# Altium schematic sheet SENSORS.SchDoc
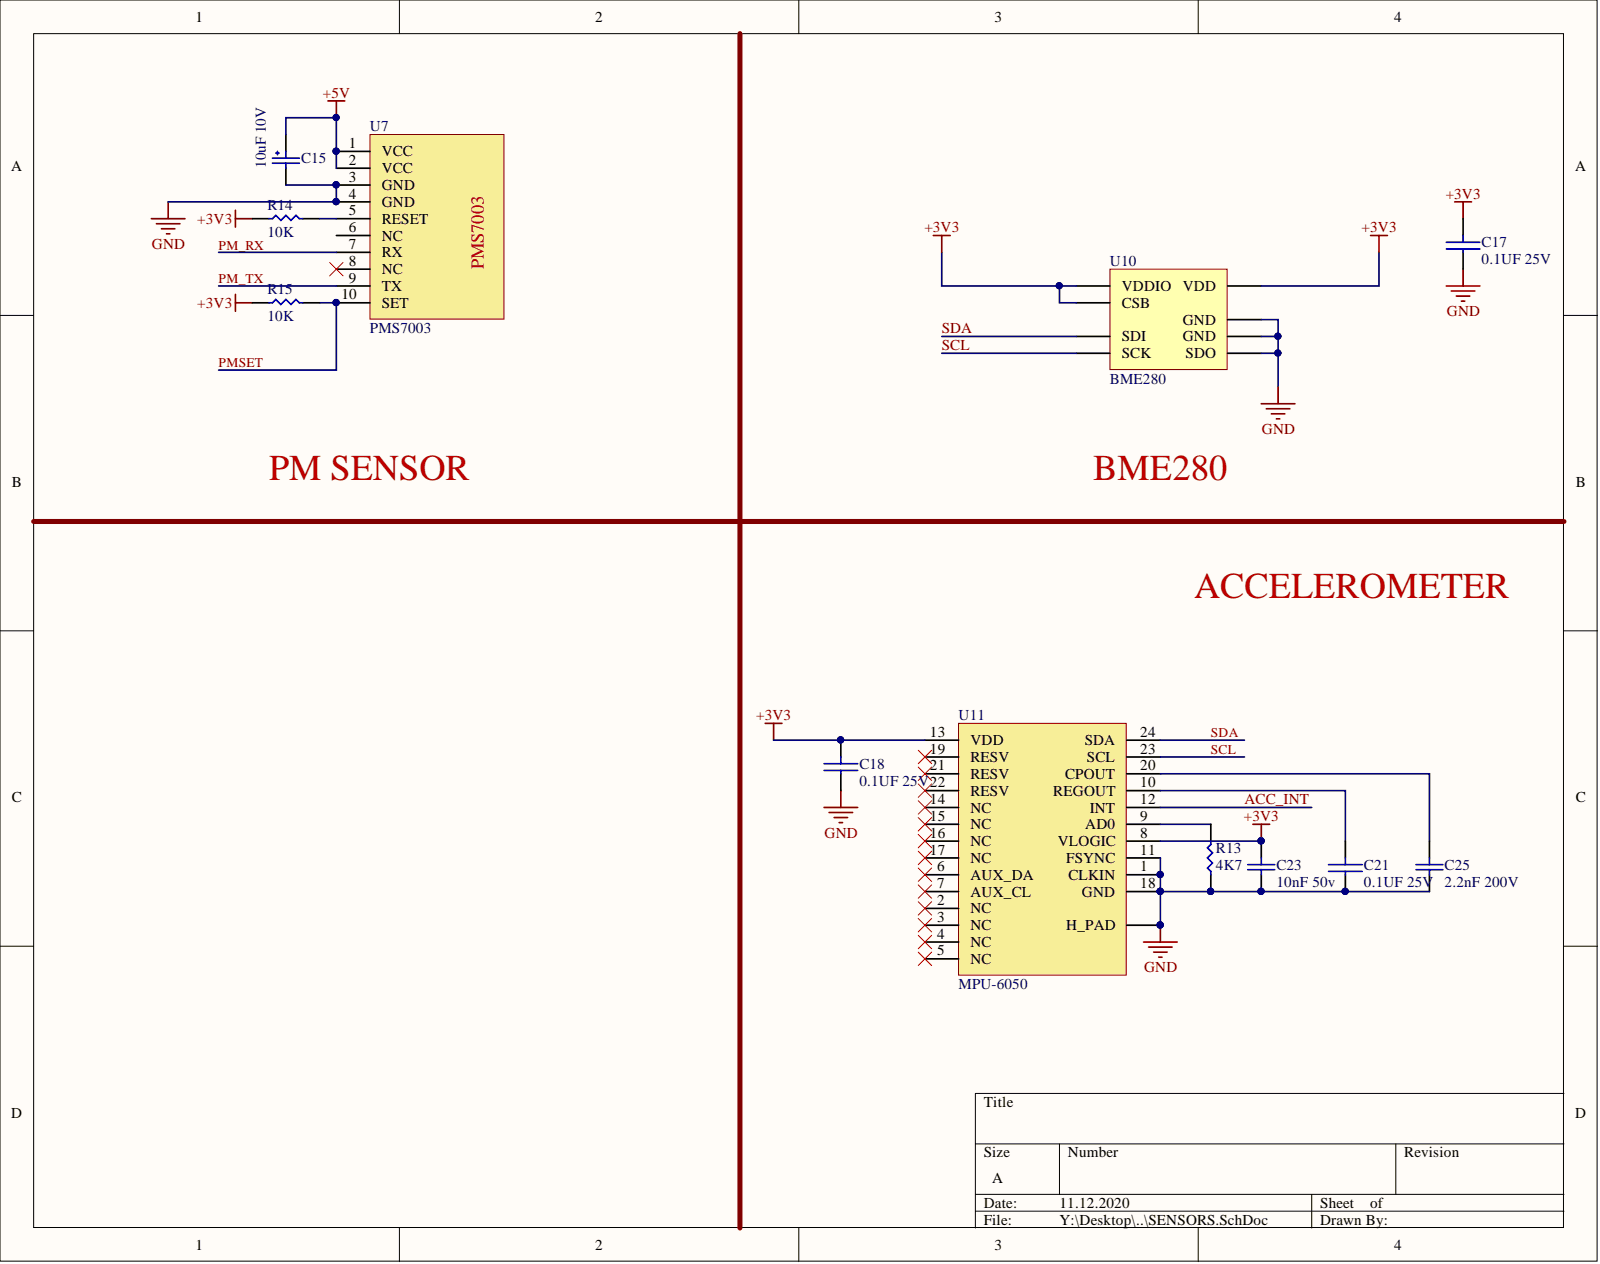
[diagram p0: SENSORS.SchDoc]

## Parts
C15  10uF 10V  [C3216]
C17  0.1UF 25V  [C0603]
C18  0.1UF 25V  [C0603]
C21  0.1UF 25V  [C0603]
C23  10nF 50v  [C0603]
C25  2.2nF 200V  [C0603]
R13  4K7  [R0603]
R14  10K  [R0603]
R15  10K  [R0603]
U7  PMS7003  [PMS7003]
U10  BME280  [BME280]
U11  MPU-6050  [MPU6050]

## Nets
+3V3: C17.2 C18.2 C23.2 R14.1 R15.1 U10.2(CSB) U10.6(VDDIO) U10.8(VDD) U11.8(VLOGIC) U11.13(VDD)
+5V: C15.2 U7.1(VCC) U7.2(VCC)
GND: C15.1 C17.1 C18.1 C21.1 C23.1 C25.1 R13.1 U7.3(GND) U7.4(GND) U10.1(GND) U10.5(SDO) U10.7(GND) U11.1(CLKIN) U11.11(FSYNC) U11.18(GND) U11.25(H_PAD)
ACC_INT: U11.12(INT)
PM_RX: U7.7(RX)
PM_TX: U7.9(TX)
PMSET: R15.2 U7.10(SET)
SCL: U10.4(SCK) U11.23(SCL)
SDA: U10.3(SDI) U11.24(SDA)
NetC21_2: C21.2 U11.10(REGOUT)
NetC25_2: C25.2 U11.20(CPOUT)
NetR13_2: R13.2 U11.9(AD0)
NetR14_2: R14.2 U7.5(RESET)
N00081:
N00082:

Not connected: U7 6(NC) 8(NC); U11 2(NC) 3(NC) 4(NC) 5(NC) 6(AUX_DA) 7(AUX_CL) 14(NC) 15(NC) 16(NC) 17(NC) 19(RESV) 21(RESV) 22(RESV)

## Library data of the parts
C15 = T491A106K010AT: CAP TANT 10UF 10V 10% 1206; Manufacturer: KEMET; Manufacturer Size Code: A; ESR (Equivalent Series Resistance): 3.8 Ohm; Lifetime @ Temp.: 2000 Hrs @ 85°C; Type: Molded; Category: Capacitors; Component Kind: Standard; ComponentLink1Description: http://www.kemet.com/Lists/ProductCatalog/Attachments/254/KEM_T2005_T491.pdf; ComponentLink1URL: http://www.kemet.com/Lists/ProductCatalog/Attachments/254/KEM_T2005_T491.pdf; Failure Rate: -; Features: General Purpose; Height - Seated (Max): 0.071" (1.80mm); Lead Spacing: -; Manufacturer Part Number: T491A106K010AT; Mounting Type: Surface Mount; Operating Temperature: -55°C ~ 125°C; Package / Case: 1206 (3216 Metric); Packaging: Cut Tape (CT); Part Status: Active; Pricing 1: 1=0.41, 10=0.287, 100=0.1721, 500=0.12912, 1000=0.10903 (USD); RoHS: RoHS Compliant; Size / Dimension: 0.126" L x 0.063" W (3.20mm x 1.60mm); Stock 1: 741056; Supplier 1: Digi-Key; Supplier Part Number 1: 399-3684-1-ND; Tolerance: ±10%; Library Name: salcox.IntLib
C17 C18 C21 = 06033D104KAT2A: CAP CER 0.1UF 25V X5R 0603; Manufacturer: Kyocera AVX; Capacitance: 100 nF; Tolerance (%): ±10 %; Case Size/Dimension: 0603; Component Kind: Standard; ComponentLink1Description: https://datasheet.ciiva.com/19475/1031061-19475906.pdf; ComponentLink1URL: https://datasheet.ciiva.com/19475/1031061-19475906.pdf; ComponentLink2Description: https://datasheet.ciiva.com/28613/759405-28613274.pdf; ComponentLink2URL: https://datasheet.ciiva.com/28613/759405-28613274.pdf; ComponentLink3Description: https://datasheet.ciiva.com/16397/cx5r-16397162.pdf; ComponentLink3URL: https://datasheet.ciiva.com/16397/cx5r-16397162.pdf; ComponentLink4Description: https://datasheet.ciiva.com/28613/1666675-28613037.pdf; ComponentLink4URL: https://datasheet.ciiva.com/28613/1666675-28613037.pdf; ComponentLink5Description: https://datasheet.ciiva.com/28613/1666675-28613275.pdf; ComponentLink5URL: https://datasheet.ciiva.com/28613/1666675-28613275.pdf; ComponentLink6Description: https://datasheet.ciiva.com/152/cx5r-152227.pdf; ComponentLink6URL: https://datasheet.ciiva.com/152/cx5r-152227.pdf; ComponentLink7Description: https://datasheet.ciiva.com/152/cx5r-152226.pdf; ComponentLink7URL: https://datasheet.ciiva.com/152/cx5r-152226.pdf; ComponentLink8Description: https://datasheet.ciiva.com/2987/cx5r-2987761.pdf; ComponentLink8URL: https://datasheet.ciiva.com/2987/cx5r-2987761.pdf; ComponentLink9Description: https://datasheet.ciiva.com/152/cx5r-152225.pdf; ComponentLink9URL: https://datasheet.ciiva.com/152/cx5r-152225.pdf; ComponentLink10Description: https://datasheet.ciiva.com/2987/cx5r-2987762.pdf; ComponentLink10URL: https://datasheet.ciiva.com/2987/cx5r-2987762.pdf; Dielectric: X5R; Manufacturer Part Number: 06033D104KAT2A; Pricing 1: 1=0.32, 10=0.221, 100=0.1328, 500=0.0996, 1000=0.0841 (USD); Rated Voltage: 25 V; Stock 1: 0; Supplier 1: Digi-Key; Supplier Part Number 1: 478-1244-6-ND; Library Name: salcox.IntLib
C23 = CC0603KRX7R9BB103 (2): Cap Ceramic 0.01uF 50V X7R 10% SMD 0603 125C Automotive T/R; Manufacturer: Yageo; Capacitance: 10000pF; Featured Product: Commodity Multilayer Ceramic Capacitors – CC Series; Online Catalog: CC Series; Other Names: 311-1085-6; Product Training Modules: Multilayer Ceramic Capacitors; Applications: General Purpose; Category: Capacitors; Component Kind: Standard; ComponentLink1Description: http://www.farnell.com/datasheets/2167236.pdf?src-supplier=Element14; ComponentLink1URL: http://www.farnell.com/datasheets/2167236.pdf?src-supplier=Element14; ComponentLink2Description: http://www.yageo.com/documents/recent/UPY-GPHC_X7R_6.3V-to-50V_18.pdf?src-supplier=Digi-Key; ComponentLink2URL: http://www.yageo.com/documents/recent/UPY-GPHC_X7R_6.3V-to-50V_18.pdf?src-supplier=Digi-Key; ComponentLink3Description: http://www.farnell.com/datasheets/2167236.pdf?src-supplier=Mouser; ComponentLink3URL: http://www.farnell.com/datasheets/2167236.pdf?src-supplier=Mouser; ComponentLink4Description: http://www.farnell.com/datasheets/70765.pdf?src-supplier=Element14; ComponentLink4URL: http://www.farnell.com/datasheets/70765.pdf?src-supplier=Element14; ComponentLink5Description: http://www.yageo.com/documents/recent/UPY-GPHC_X7R_6.3V-to-50V_8.pdf?src-supplier=Avnet+Europe; ComponentLink5URL: http://www.yageo.com/documents/recent/UPY-GPHC_X7R_6.3V-to-50V_8.pdf?src-supplier=Avnet+Europe; ComponentLink6Description: http://www.farnell.com/datasheets/1489800.pdf?src-supplier=Element14; ComponentLink6URL: http://www.farnell.com/datasheets/1489800.pdf?src-supplier=Element14; ComponentLink7Description: https://www.verical.com/server-webapp/html/getDatasheet?partID=403582&src-supplier=Verical; ComponentLink7URL: https://www.verical.com/server-webapp/html/getDatasheet?partID=403582&src-supplier=Verical; Family: Ceramic Capacitors; Features: -; Height - Seated (Max): -; Lead Spacing: -; Lead Style: -; Manufacturer Part Number: CC0603KRX7R9BB103; Mounting Type: Surface Mount, MLCC; Operating Temperature: -55°C ~ 125°C; Package / Case: 0603 (1608 Metric); Packaging: Digi-Reel®; Pricing 1: 1=0.1, 10=0.037, 100=0.0165, 500=0.0118, 1000=0.0092 (USD); Ratings: -; Series: CC; Size / Dimension: 0.063" L x 0.031" W (1.60mm x 0.80mm); Standard Package: 1; Stock 1: 7014916; Supplier 1: Digi-Key; Supplier Part Number 1: 311-1085-1-ND; Temperature Coefficient: X7R; Thickness (Max): 0.035" (0.90mm); Tolerance: ±10%; Voltage - Rated: 50V; Library Name: salcoxschlib.SchLib
C25 = C0603C222K2RACTU: CAP CER 2200PF 200V X7R 0603; Part # Aliases: C0603C222K2RAC7867; Brand: Kemet; Capacitance - uF: 0.0022 uF; Case Height: 0.8 mm; Case Length: 1.6 mm; Class: Class 1; Dissipation Factor DF: 10; Temperature Coefficient / Code: +/- 15 %; Tolerance: 10 %; Type: SMD MLCC X7R 6.3 - 250 VDC Commerical Grade; Capacitance: 2200 pF; Capacitance - nF: 2.2 nF; Case Code - in: 0603; Case Code - mm: 1608; Case Width: 0.8 mm; Component Kind: Standard; ComponentLink1Description: http://www.farnell.com/datasheets/1633156.pdf?src-supplier=Element14; ComponentLink1URL: http://www.farnell.com/datasheets/1633156.pdf?src-supplier=Element14; ComponentLink2Description: http://www.farnell.com/datasheets/1851736.pdf?src-supplier=Element14; ComponentLink2URL: http://www.farnell.com/datasheets/1851736.pdf?src-supplier=Element14; Dielectric: X7R; Dimensions: 0.8 mm W x 1.6 mm L x 0.8 mm H; Factory Pack Quantity: 4000; Manufacturer: KEMET; Manufacturer Part Number: C0603C222K2RACTU; Maximum Operating Temperature: + 125 C; Minimum Operating Temperature: - 55 C; Operating Temperature Range: - 55 C to + 125 C; Package / Case: 0603 (1608 metric); Packaging: Reel; Pricing 1: 1=0.38, 10=0.262, 100=0.157, 500=0.1177, 1000=0.0994 (USD); Product: General Type MLCCs; Product Category: Multilayer Ceramic Capacitors MLCC - SMD/SMT; RoHS: Details; Series: C0603C; Stock 1: 6991; Supplier 1: Digi-Key; Supplier Part Number 1: 399-14504-1-ND; Termination Style: SMD/SMT; Voltage Rating: 200 V; Voltage Rating DC: 200 V; Library Name: salcoxschlib.SchLib
R13 = CRCW06034K70FKEA: RES SMD 4.7K OHM 1% 1/10W 0603; Manufacturer: Vishay; Categories: Resistors; Power (Watts): 0.1W, 1/10W; Resistance: 4.7 kOhms; Temperature Coefficient: ±100ppm/°C; Component Kind: Standard; ComponentLink1Description: http://uk.rs-online.com/webdocs/0d98/0900766b80d98afc.pdf?src-supplier=RSComponents; ComponentLink1URL: http://uk.rs-online.com/webdocs/0d98/0900766b80d98afc.pdf?src-supplier=RSComponents; ComponentLink2Description: https://www.verical.com/server-webapp/html/getDatasheet?partID=33073&src-supplier=Verical; ComponentLink2URL: https://www.verical.com/server-webapp/html/getDatasheet?partID=33073&src-supplier=Verical; ComponentLink3Description: http://www.vishay.com/docs/20035/dcrcwe3.pdf?src-supplier=Future+Electronics; ComponentLink3URL: http://www.vishay.com/docs/20035/dcrcwe3.pdf?src-supplier=Future+Electronics; ComponentLink4Description: https://www.alliedelec.com/m/d/0c934d6939ccefa68dbe0b96d821c8cf.pdf?src-supplier=Allied+Electronics; ComponentLink4URL: https://www.alliedelec.com/m/d/0c934d6939ccefa68dbe0b96d821c8cf.pdf?src-supplier=Allied+Electronics; ComponentLink5Description: http://www.vishay.com/docs/20035/dcrcwe3.pdf?src-supplier=Avnet+Asia; ComponentLink5URL: http://www.vishay.com/docs/20035/dcrcwe3.pdf?src-supplier=Avnet+Asia; ComponentLink6Description: http://www.farnell.com/datasheets/2046716.pdf?src-supplier=Element14; ComponentLink6URL: http://www.farnell.com/datasheets/2046716.pdf?src-supplier=Element14; ComponentLink7Description: https://www.distrelec.biz/Web/Downloads/a_/en/flD-CRCW-e3_data_en.pdf?mime=application%2Fpdf&src-supplier=Distrelec; ComponentLink7URL: https://www.distrelec.biz/Web/Downloads/a_/en/flD-CRCW-e3_data_en.pdf?mime=application%2Fpdf&src-supplier=Distrelec; Composition: Thick Film; Failure Rate: -; Features: Automotive AEC-Q200; Height - Seated (Max): 0.020 (0.50mm); Manufacturer Part Number: CRCW06034K70FKEA; Number of Terminations: 2; Operating Temperature: -55°C ~ 155°C; Package / Case: 0603 (1608 Metric); Packaging: Digi-Reel®; Part Status: Active; Pricing 1: 1=0.1, 10=0.036, 100=0.0146, 1000=0.0065, 2500=0.0057 (USD); Series: CRCW; Size / Dimension: 0.061 L x 0.033 W (1.55mm x 0.85mm); Stock 1: 0; Supplier 1: Digi-Key; Supplier Device Package: 0603; Supplier Part Number 1: 541-4.70KHCT-ND; Tolerance: ±1%; Library Name: salcoxschlib.SchLib
R14 R15 = RC0603FR-0710KL: RES SMD 10K OHM 1% 1/10W 0603; Manufacturer: Yageo; Power (Watts): 0.1W, 1/10W; Temperature Coefficient: ±100ppm/°C; Category: Resistors; Component Kind: Standard; ComponentLink1Description: http://www.yageo.com/documents/recent/PYu-RC_Group_51_RoHS_L_8.pdf; ComponentLink1URL: http://www.yageo.com/documents/recent/PYu-RC_Group_51_RoHS_L_8.pdf; Composition: Thick Film; Failure Rate: -; Features: Moisture Resistant; Height - Seated (Max): 0.022" (0.55mm); Manufacturer Part Number: RC0603FR-0710KL; Operating Temperature: -55°C ~ 155°C; Package / Case: 0603 (1608 Metric); Packaging: Digi-Reel®; Part Status: Active; Pricing 1: 1=0.1, 10=0.015, 100=0.006, 1000=0.00268, 2500=0.00233 (USD); RoHS: RoHS Compliant; Size / Dimension: 0.063" L x 0.032" W (1.60mm x 0.80mm); Stock 1: 5916612; Supplier 1: Digi-Key; Supplier Device Package: 0603; Supplier Part Number 1: 311-10.0KHRDKR-ND; Tolerance: ±1%; Library Name: salcox.IntLib
U10 = BME280: Pressure, Temperature and Humidity Sensor Digital Output 1.8V 8-Pin LGA T/R; Manufacturer: Bosch; Accuracy: ±3%; Categories: Sensors, Transducers; Humidity Range: 0 ~ 100% RH; Output: 16b; Output Type: I²C, SPI; Response Time: 1s; Sensor Type: Humidity, Pressure, Temperature; Voltage - Supply: 1.71 V ~ 3.6 V; Component Kind: Standard; ComponentLink1Description: https://ae-bst.resource.bosch.com/media/_tech/media/datasheets/BST-BME280-DS002.pdf?src-supplier=Digi-Key; ComponentLink1URL: https://ae-bst.resource.bosch.com/media/_tech/media/datasheets/BST-BME280-DS002.pdf?src-supplier=Digi-Key; ComponentLink2Description: https://ae-bst.resource.bosch.com/media/_tech/media/product_flyer/BME280_Productflyer_BST_20170109.pdf?src-supplier=Arrow; ComponentLink2URL: https://ae-bst.resource.bosch.com/media/_tech/media/product_flyer/BME280_Productflyer_BST_20170109.pdf?src-supplier=Arrow; ComponentLink3Description: https://ae-bst.resource.bosch.com/media/_tech/media/product_flyer/BME280_Productflyer_BST_20170109.pdf?src-supplier=Mouser; ComponentLink3URL: https://ae-bst.resource.bosch.com/media/_tech/media/product_flyer/BME280_Productflyer_BST_20170109.pdf?src-supplier=Mouser; ComponentLink4Description: https://ae-bst.resource.bosch.com/media/_tech/media/product_flyer/BME280_Productflyer_BST_20170109.pdf?src-supplier=NAC+Semi; ComponentLink4URL: https://ae-bst.resource.bosch.com/media/_tech/media/product_flyer/BME280_Productflyer_BST_20170109.pdf?src-supplier=NAC+Semi; Manufacturer Part Number: BME280; Mounting Type: Surface Mount; Operating Temperature: -40°C ~ 85°C; Package / Case: 8-VFLGA; Packaging: Cut Tape (CT); Part Status: Active; Pricing 1: 1=6.55, 5=5.776, 10=5.101, 25=4.1388, 50=3.6576, 100=3.5613, 500=2.9838, 1000=2.7913, 5000=2.5988 (USD); Sensitivity: -; Series: -; Stock 1: 18503; Supplier 1: Digi-Key; Supplier Device Package: 8-LGA (2.5x2.5); Supplier Part Number 1: 828-1063-1-ND; Library Name: salcoxschlib.SchLib
U11 = MPU-6050: IMU ACCEL/GYRO 3-AXIS I2C 24QFN; Manufacturer: TDK InvenSense; Output Type: I²C; Sensor Type: Accelerometer, Gyroscope, 3 Axis; Category: Sensors, Transducers; Component Kind: Standard; ComponentLink1Description: http://store.invensense.com/datasheets/invensense/MPU-6050_DataSheet_V3%204.pdf; ComponentLink1URL: http://store.invensense.com/datasheets/invensense/MPU-6050_DataSheet_V3%204.pdf; Manufacturer Part Number: MPU-6050; Operating Temperature: -40°C ~ 85°C (TA); Part Status: Not For New Designs; Pricing 1: 1=8.25, 5=7.232, 10=6.509, 25=5.786, 50=5.352, 100=4.6288, 500=4.3395, 1000=4.0502 (USD); RoHS: RoHS Compliant; Stock 1: 22313; Supplier 1: Digi-Key; Supplier Part Number 1: 1428-1007-1-ND; Library Name: salcoxschlib.SchLib
U7 = PMS7003: Component Kind: Standard; Description: PMS7003; Library Name: salcox.IntLib
